# Altium schematic sheet PowerManagement.SchDoc
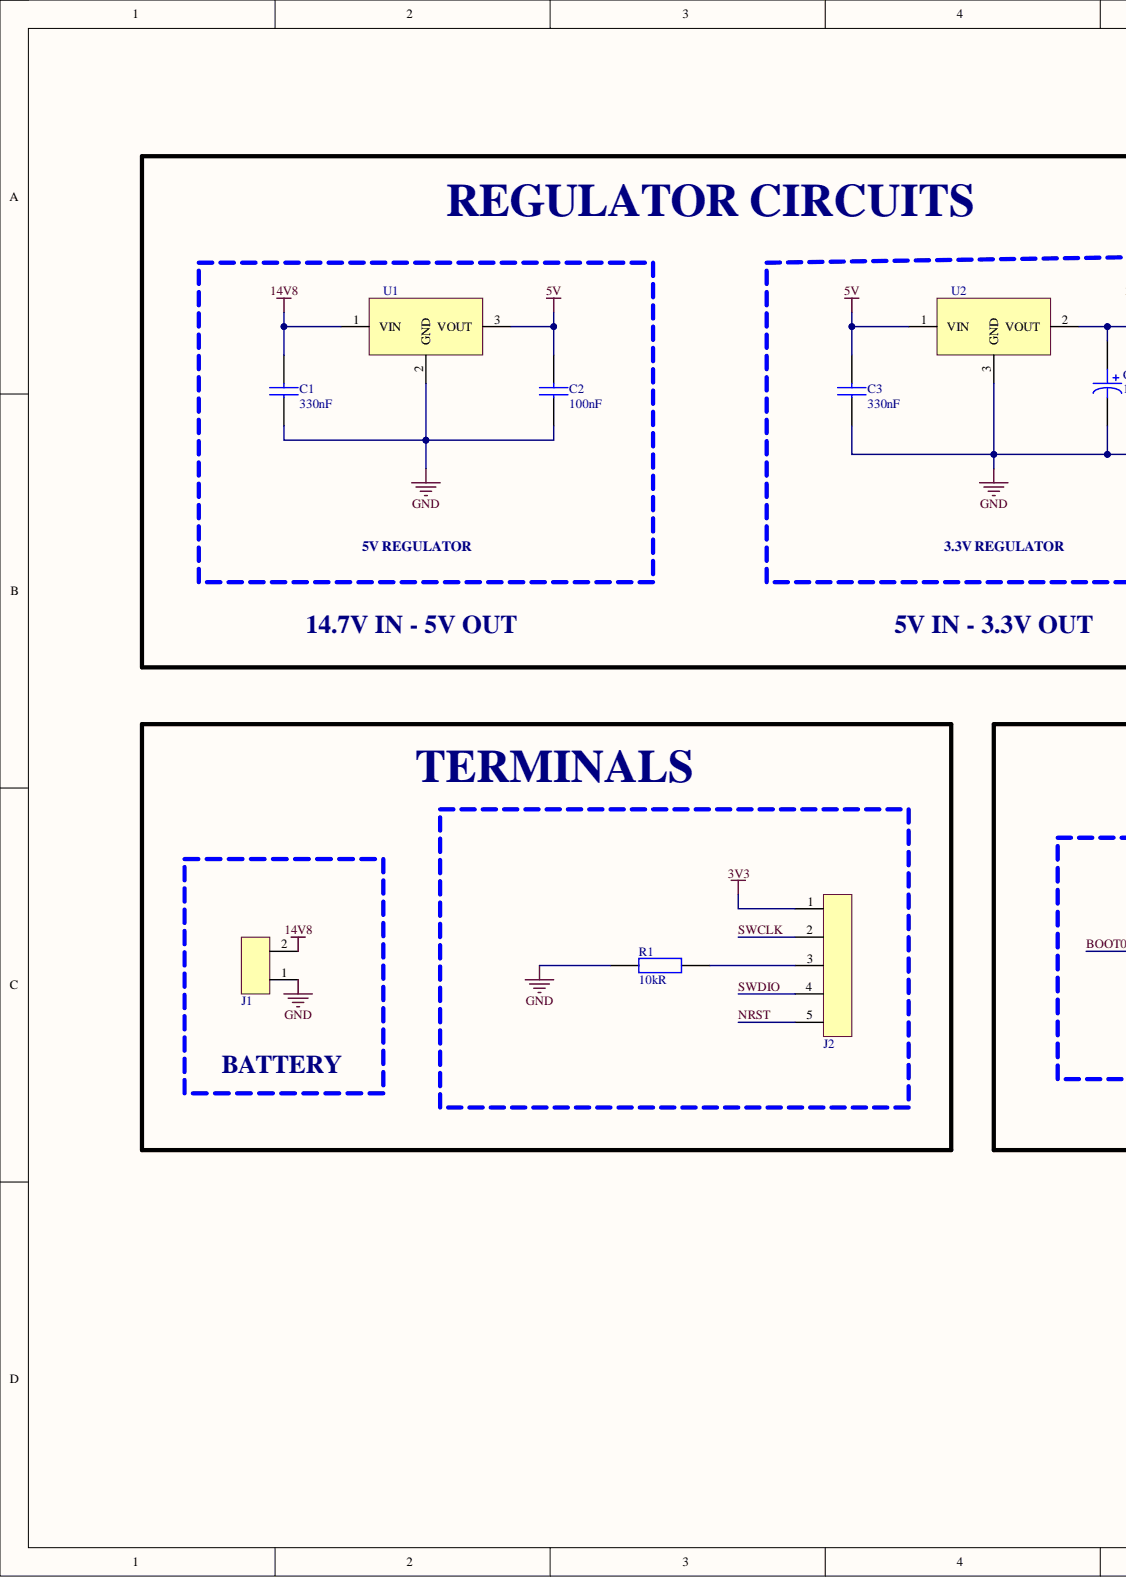
[diagram p0: PowerManagement.SchDoc, left]
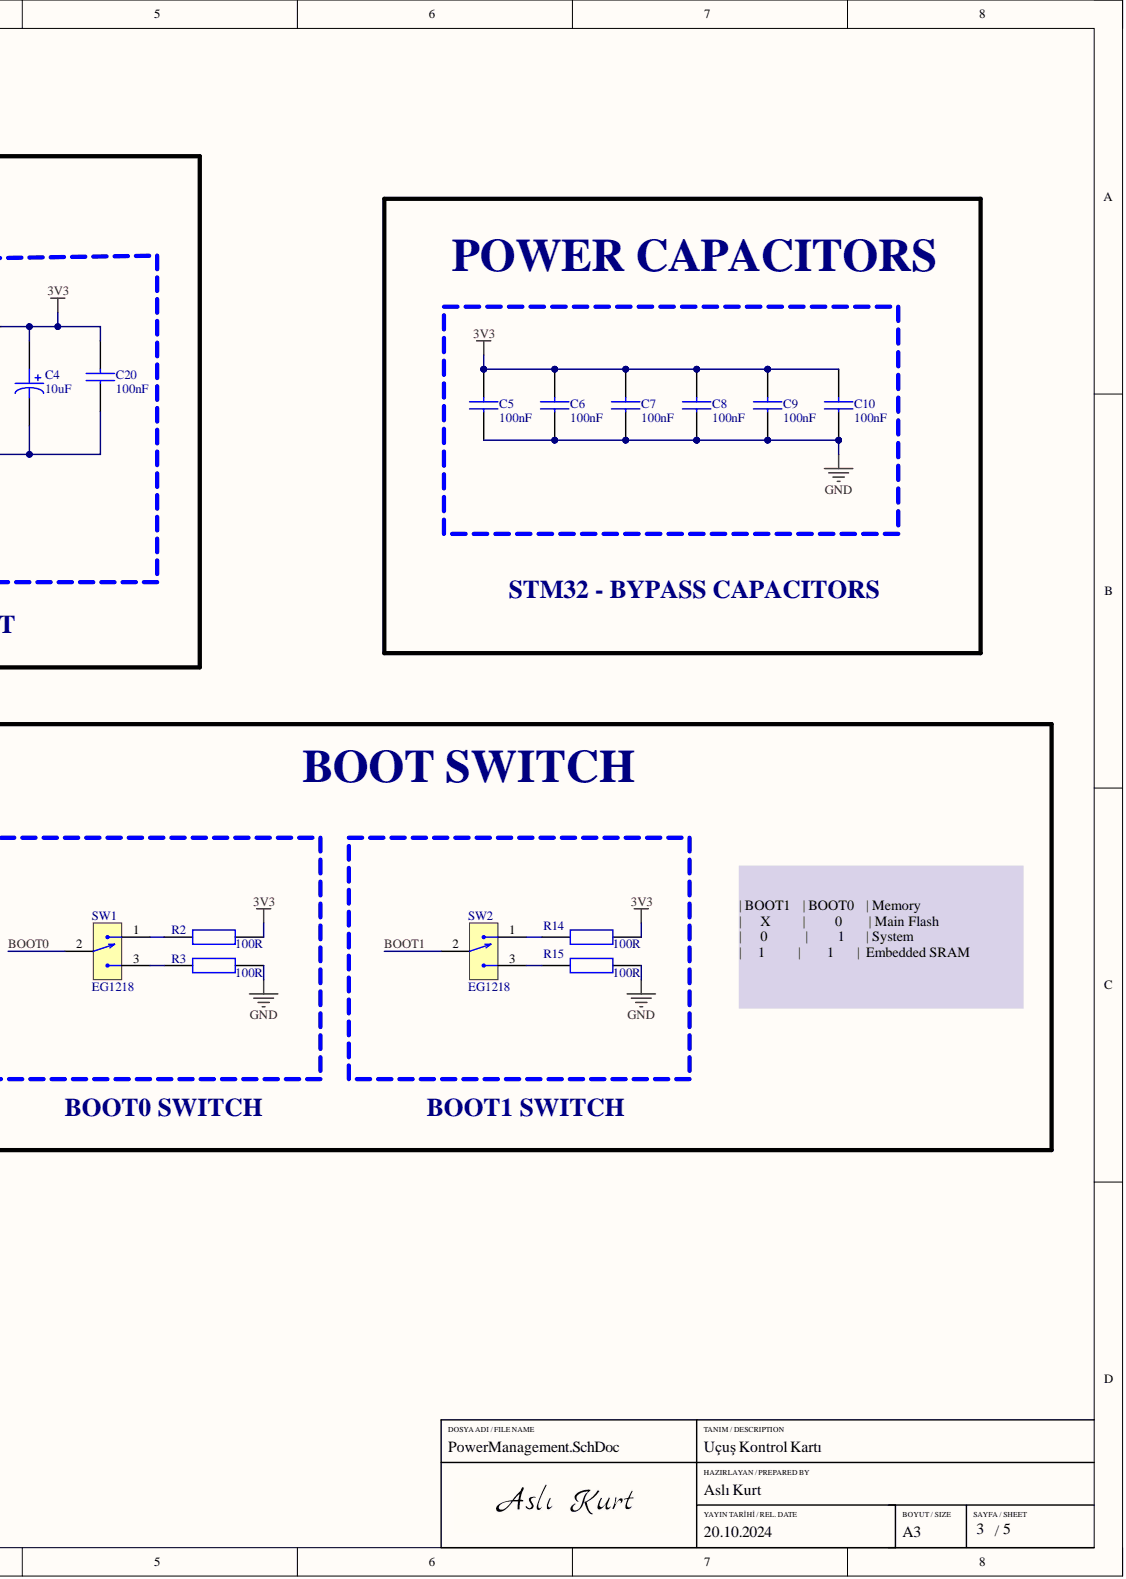
[diagram p1: PowerManagement.SchDoc, right]

## Parts
C1  Capacitance: 330nF  [CAP_0603]  (hidden comment: CGA3E3X7R1H334K080AB)
C2  Capacitance: 100nF  [CAP_0603]  (hidden comment: C0603C104K5RACTU)
C3  Capacitance: 330nF  [CAP_0603]  (hidden comment: CGA3E3X7R1H334K080AB)
C4  Capacitance: 10uF  [CAP_RADIAL_D5_P5.5_SMD]  (hidden comment: 865080642006)
C5  Capacitance: 100nF  [CAP_0603]  (hidden comment: C0603C104K5RACTU)
C6  Capacitance: 100nF  [CAP_0603]  (hidden comment: C0603C104K5RACTU)
C7  Capacitance: 100nF  [CAP_0603]  (hidden comment: C0603C104K5RACTU)
C8  Capacitance: 100nF  [CAP_0603]  (hidden comment: C0603C104K5RACTU)
C9  Capacitance: 100nF  [CAP_0603]  (hidden comment: C0603C104K5RACTU)
C10  Capacitance: 100nF  [CAP_0603]  (hidden comment: C0603C104K5RACTU)
C20  Capacitance: 100nF  [CAP_0603]  (hidden comment: C0603C104K5RACTU)
J1  282836-2  [TERMINAL]  (value not drawn)
J2  61300511121  [5x1 Male Header 2.54mm]  (value not drawn)
R1  Resistance: 10kR  [RES_0805]  (hidden comment: RC0805JR-0710KL)
R2  Resistance: 100R  [RES_0603]  (hidden comment: RC0603JR-07100RL)
R3  Resistance: 100R  [RES_0603]  (hidden comment: RC0603JR-07100RL)
R14  Resistance: 100R  [RES_0603]  (hidden comment: RC0603JR-07100RL)
R15  Resistance: 100R  [RES_0603]  (hidden comment: RC0603JR-07100RL)
SW1  EG1218  [SWITCHSLIDE_1POS_DIP]
SW2  EG1218  [SWITCHSLIDE_1POS_DIP]
U1  Nominal Output Voltage: 5V  [D2PAK-TO-263-3]  (hidden comment: L7805CD2T-TR)
U2  LD29150DT33R  [DPAK-TO252]  (value not drawn)

## Nets
3V3: C4.1 C5.2 C6.2 C7.2 C8.2 C9.2 C10.2 C20.2 J2.1 R2.2 R14.2 U2.2
5V: C2.2 C3.2 U1.3 U2.1
14V8: C1.2 J1.2 U1.1
GND: C1.1 C2.1 C3.1 C4.2 C5.1 C6.1 C7.1 C8.1 C9.1 C10.1 C20.1 J1.1 R1.1 R3.2 R15.2 U1.2 U2.3
BOOT0: SW1.2
BOOT1: SW2.2
NRST: J2.5
SWCLK: J2.2
SWDIO: J2.4
NetJ2_3: J2.3 R1.2
NetR2_1: R2.1 SW1.1
NetR3_1: R3.1 SW1.3
NetR14_1: R14.1 SW2.1
NetR15_1: R15.1 SW2.3

## Library data of the parts
C1 C3 = CAP CER 330nF 0603: Multilayer Ceramic Capacitor, 0.33 uF, 50 V, ± 10%, X7R, 0603 [1608 Metric]; Manufacturer: TDK; DesignedBy: Aslı Kurt; Material: 4241ConductorMaterial; Voltage Rating: 50V; Case Code (Metric): 1608; Case Code (Imperial): 0603; Case/Package: 0603; Category: Ceramic Capacitors; Component Kind: Standard; ComponentLink1Description: http://www.mouser.com/ds/2/400/lcc_automotive_general_en-843974.pdf?src-supplier=Mouser; ComponentLink1URL: http://www.mouser.com/ds/2/400/lcc_automotive_general_en-843974.pdf?src-supplier=Mouser; ComponentLink2Description: https://datasheet.octopart.com/CGA3E3X7R1H334K080AB-TDK-datasheet-43366117.pdf?src-supplier=Future+Electronics; ComponentLink2URL: https://datasheet.octopart.com/CGA3E3X7R1H334K080AB-TDK-datasheet-43366117.pdf?src-supplier=Future+Electronics; ComponentLink3Description: https://product.tdk.com/info/en/documents/chara_sheet/CGA3E3X7R1H334K080AB.pdf?src-supplier=Digikey; ComponentLink3URL: https://product.tdk.com/info/en/documents/chara_sheet/CGA3E3X7R1H334K080AB.pdf?src-supplier=Digikey; ComponentLink4Description: https://product.tdk.com/info/en/catalog/datasheets/mlcc_automotive_general_en.pdf?src-supplier=Digikey; ComponentLink4URL: https://product.tdk.com/info/en/catalog/datasheets/mlcc_automotive_general_en.pdf?src-supplier=Digikey; ComponentLink5Description: http://product.tdk.com/capacitor/mlcc/class/print_detail_pdf.php?lang=en&part_no=CGA3E3X7R1H334K080AB&src-supplier=Avnet; ComponentLink5URL: http://product.tdk.com/capacitor/mlcc/class/print_detail_pdf.php?lang=en&part_no=CGA3E3X7R1H334K080AB&src-supplier=Avnet; ComponentLink6Description: https://datasheet.octopart.com/CGA3E3X7R1H334K080AB-TDK-datasheet-165583812.pdf?src-supplier=TME; ComponentLink6URL: https://datasheet.octopart.com/CGA3E3X7R1H334K080AB-TDK-datasheet-165583812.pdf?src-supplier=TME; Dielectric: X7R; Height: 0.8mm; Length: 0.063inch; Library Name: MyLibrary.IntLib; Manufacturer Part Number: CGA3E3X7R1H334K080AB; Max Operating Temperature: 125°C; Min Operating Temperature: -55°C; Mount: Surface Mount; Package Quantity: 4000; Packaging: Tape and Reel; Pricing 1: 50=0,082, 250=0,066, 500=0,058, 1000=0,049 (GBP); Pricing 2: 1=0,231, 10=0,105, 25=0,093, 50=0,08, 100=0,068 (USD); Pricing 3: 10=0,156, 100=0,0848, 500=0,0677, 1000=0,0505, 2000=0,0453, 4000=0,04, 20000=0,0371, 40000=0,0362 (EUR); Pricing 4: 1=0,23, 10=0,098, 50=0,098, 100=0,063, 1000=0,053, 10000=0,032 (USD); Pricing 5: 1=0,24, 10=0,139, 50=0,0982, 100=0,0858, 500=0,06458, 1000=0,05797 (USD); Ratings: AEC-Q200; RoHS: Yes; RoHS Compliant: Yes; Series: CGA; Stock 1: 1350; Stock 2: 26394; Stock 3: 76750; Stock 4: 154875; Stock 5: 1242048; Supplier 1: Rs; Supplier 2: Avnet; Supplier 3: Farnell; Supplier 4: Mouser; Supplier 5: Digikey; Supplier Part Number 1: 2427476; Supplier Part Number 2: 71AH2809; Supplier Part Number 3: 3416368; Supplier Part Number 4: 810-CGA3E3X7R1H334KB; Supplier Part Number 5: 445-174426-1-ND; Termination: SMD/SMT; Thickness: 0.035inch; Tolerance: 10%; Voltage Rating (DC): 50V; Weight: 7.1E-05oz; Width: 0.031inch
C2 C5-C10 C20 = CAP CER 100nF 0603: CAP CER 100nF %10 0603 SMD; Manufacturer: KEMET; DesignedBy: Aslı Kurt; Dielectric: X7R; Dielectric Material: Ceramic; Dissipation Factor: 2.5%; Tolerance: 10%; Voltage: 50V; Case Code (Metric): 1608; Case Code (Imperial): 0603; Case/Package: 0603; Category: Ceramic Capacitors; Component Kind: Standard; ComponentLink1Description: https://datasheet.ciiva.com/7823/kem-c1002-x7r-smd-7823591.pdf?src-supplier=Element14; ComponentLink1URL: https://datasheet.ciiva.com/7823/kem-c1002-x7r-smd-7823591.pdf?src-supplier=Element14; ComponentLink2Description: https://datasheet.ciiva.com/14602/70095383-14602583.pdf?src-supplier=Allied+Electronics; ComponentLink2URL: https://datasheet.ciiva.com/14602/70095383-14602583.pdf?src-supplier=Allied+Electronics; ComponentLink3Description: https://datasheet.ciiva.com/5999/kem-c1002-x7r-smd-5999400.pdf?src-supplier=Farnell; ComponentLink3URL: https://datasheet.ciiva.com/5999/kem-c1002-x7r-smd-5999400.pdf?src-supplier=Farnell; ComponentLink4Description: https://datasheet.ciiva.com/7823/kem-c1002-x7r-smd-7823592.pdf?src-supplier=Element14; ComponentLink4URL: https://datasheet.ciiva.com/7823/kem-c1002-x7r-smd-7823592.pdf?src-supplier=Element14; ComponentLink5Description: https://datasheet.ciiva.com/28645/1851736-28645640.pdf?src-supplier=Element14; ComponentLink5URL: https://datasheet.ciiva.com/28645/1851736-28645640.pdf?src-supplier=Element14; ComponentLink6Description: https://datasheet.ciiva.com/14585/70095383-14585209.pdf?src-supplier=Allied+Electronics; ComponentLink6URL: https://datasheet.ciiva.com/14585/70095383-14585209.pdf?src-supplier=Allied+Electronics; Contact Plating: Tin,Matte; Depth: 0.8mm; Height: 0.8mm; Lead Pitch: 0.7mm; Length: 0.063inch; Library Name: MyLibrary.IntLib; Manufacturer Part Number: C0603C104K5RACTU; Max Operating Temperature: 125°C; Min Operating Temperature: -55°C; Mount: Surface Mount; Number of Pins: 2; Package Quantity: 1; Packaging: Tape and Reel; Pricing 1: 1=0,12000, 10=0,08500, 50=0,04620, 100=0,03770, 500=0,02696, 1000=0,02118 (USD); Radiation Hardening: No; REACH SVHC: No SVHC; RoHS: Yes; RoHS Compliant: Yes; Series: SMD Comm X7R; Stock 1: 1666186; Supplier 1: Digi-Key; Supplier Part Number 1: 399-5089-1-ND; Termination: SMD/SMT; Thickness: 0.034inch; Voltage Rating: 50V; Voltage Rating (DC): 50V; Width: 0.031inch
C4 = CAP ALU ELEC 10uF  50V: CAP ALUM 10UF 20% 50V SMD; Manufacturer: Wurth Electronics; DesignedBy: Aslı Kurt; ESR (Equivalent Series Resistance): 1.63932R; Leakage Current: 5uA; Ripple Current: 95mA; Impedance: 2.8R; Insulation Resistance: 10MR; Case/Package: Radial; Category: Aluminum Electrolytic Capacitors; Component Kind: Standard; ComponentLink1Description: http://www.farnell.com/datasheets/1880176.pdf?src-supplier=Farnell; ComponentLink1URL: http://www.farnell.com/datasheets/1880176.pdf?src-supplier=Farnell; ComponentLink2Description: http://www.farnell.com/datasheets/1880176.pdf?src-supplier=Element14; ComponentLink2URL: http://www.farnell.com/datasheets/1880176.pdf?src-supplier=Element14; ComponentLink3Description: http://www.farnell.com/datasheets/1880176.pdf?src-supplier=Newark; ComponentLink3URL: http://www.farnell.com/datasheets/1880176.pdf?src-supplier=Newark; ComponentLink4Description: http://katalog.we-online.de/pbs/datasheet/865080642006.pdf?src-supplier=Digikey; ComponentLink4URL: http://katalog.we-online.de/pbs/datasheet/865080642006.pdf?src-supplier=Digikey; ComponentLink5Description: https://katalog.we-online.de/pbs/datasheet/865080642006.pdf?src-supplier=Digikey; ComponentLink5URL: https://katalog.we-online.de/pbs/datasheet/865080642006.pdf?src-supplier=Digikey; Diameter: 5mm; Height: 5.5mm; Height - Seated (Max): 0.217inch; Length: 5.5mm; Library Name: MyLibrary.IntLib; Manufacturer Part Number: 865080642006; Max Operating Temperature: 105°C; Min Operating Temperature: -55°C; Mount: Surface Mount; Package Quantity: 1; Packaging: Cut Tape; Pricing 1: 25=0,16 (GBP); Pricing 2: 1=0,27, 50=0,165, 100=0,154, 250=0,128, 500=0,112, 1000=0,108, 5000=0,107, 10000=0,105 (EUR); Pricing 3: 1=0,2, 10=0,2, 50=0,174, 100=0,151, 1000=0,112, 10000=0,112 (USD); Pricing 4: 1=0,2, 50=0,174, 100=0,151, 250=0,134, 500=0,118 (USD); Reach SVHC Compliant: Unknown; Ripple Current (AC): 95mA; RoHS: Yes; RoHS Compliant: Yes; Series: WCAP-ASLI; Stock 1: 250; Stock 2: 875; Stock 3: 13568; Stock 4: 72984; Supplier 1: Rs; Supplier 2: Farnell; Supplier 3: Mouser; Supplier 4: Digikey; Supplier Part Number 1: 8391862; Supplier Part Number 2: 2466333; Supplier Part Number 3: 710-865080642006; Supplier Part Number 4: 732-8451-1-ND; Tolerance: 20%; Voltage Rating: 50V; Voltage Rating (DC): 50V; Width: 5.3mm
J1 = 2x1 TERMINAL 5mm: Connector, Terminal Block; Wire Receptacle; 2 Pos.; 16-30 AWG; 0.197 in. CL; Sid; Manufacturer: TE Connectivity; Approvals: UL; Closed End: No (Without); Connector System: 5937ConnectorSystem; Current: 13.5A; DesignedBy: Aslı Kurt; Max Supply Voltage (AC): 300V; Number of Circuits: 2; Number of Levels: 1; Pitch: 5mm; Plating: Brass; Side Stackable: Yes (With); Tail Length: 3.5mm; Thread Size: M3; Voltage Rating (DC): 250V; Wire Gauge (Max): 30AWG; Category: Terminal Blocks; Color: Green; Component Kind: Standard; ComponentLink1Description: https://datasheet.ciiva.com/7628/1731782-7628513.pdf?src-supplier=Element14; ComponentLink1URL: https://datasheet.ciiva.com/7628/1731782-7628513.pdf?src-supplier=Element14; ComponentLink2Description: https://datasheet.ciiva.com/16393/282836-2-16393382.pdf?src-supplier=Future+Electronics; ComponentLink2URL: https://datasheet.ciiva.com/16393/282836-2-16393382.pdf?src-supplier=Future+Electronics; ComponentLink3Description: https://datasheet.ciiva.com/15169/getdatasheetpartid-155855-15169553.pdf?src-supplier=Verical; ComponentLink3URL: https://datasheet.ciiva.com/15169/getdatasheetpartid-155855-15169553.pdf?src-supplier=Verical; ComponentLink4Description: https://datasheet.ciiva.com/11757/0900766b8002dc8a-11757385.pdf?src-supplier=Rs; ComponentLink4URL: https://datasheet.ciiva.com/11757/0900766b8002dc8a-11757385.pdf?src-supplier=Rs; ComponentLink5Description: https://datasheet.ciiva.com/823/eng-cd-282836-h10-823437.pdf?src-supplier=Digikey; ComponentLink5URL: https://datasheet.ciiva.com/823/eng-cd-282836-h10-823437.pdf?src-supplier=Digikey; ComponentLink6Description: https://datasheet.ciiva.com/3141/eng-cs-1308389-eurostyle-terminal-blocks-0607-3141118.pdf?src-supplier=Avnet; ComponentLink6URL: https://datasheet.ciiva.com/3141/eng-cs-1308389-eurostyle-terminal-blocks-0607-3141118.pdf?src-supplier=Avnet; ComponentLink7Description: https://datasheet.ciiva.com/30261/eng-cs-1308389-eurostyle-terminal-blocks-0607-30261813.pdf?src-supplier=Onlinecomponents.com; ComponentLink7URL: https://datasheet.ciiva.com/30261/eng-cs-1308389-eurostyle-terminal-blocks-0607-30261813.pdf?src-supplier=Onlinecomponents.com; Connector Type: Receptacle; Contact Material: Brass; Contact Plating: Tin; Current Rating: 13.5A; Depth: 8.2mm; ELV: Compliant; Flammability Rating: UL94 V-0; Housing Color: Green; Housing Material: Polyamide; Lead Free: Lead Free; Length: 10.6mm; Library Name: MyLibrary.IntLib; Manufacturer Part Number: 282836-2; Max Current Rating: 13.5A; Max Operating Temperature: 105°C; Max Voltage Rating (AC): 300V; Min Operating Temperature: -40°C; Mount: Through Hole; Number of Contacts: 2; Number of Poles: 2; Number of Positions: 2; Number of Positions Per Level: 2; Number of Rows: 1; Operating Supply Voltage: 300V; Orientation: Straight; Package Quantity: 300; Packaging: Tray; Pricing 1: 1=0,6, 10=0,578, 25=0,576, 50=0,5566, 100=0,4666, 300=0,43743, 600=0,41315, 1200=0,36211, 5100=0,31593 (USD); Radiation Hardening: No; Reach SVHC Compliant: Unknown; RoHS: Yes; RoHS Compliant: Yes; Screw Flange: No (Without); Screwless Terminal Block: No (Without); Series: Buchanan; Stock 1: 33835; Supplier 1: Digikey; Supplier Part Number 1: A98076-ND; Termination: Solder; Voltage: 300V; Voltage Rating: 300V; Width: 10mm; Wire Gauge (Min): 30AWG; Wire/Cable Gauge: 30AWG; Insulation Material: Nylon; Interlock: Yes (With)
J2 = 5x1 Male Header 2.54mm: DesignedBy: Aslı Kurt; Component Kind: Standard; Library Name: MyLibrary.IntLib
R1 = RES 10K OHM 0805: RES 10K OHM 5% 1/8W 0805; Manufacturer: Yageo; DesignedBy: Aslı Kurt; Max Power Dissipation: 125mW; Temperature Coefficient: 100ppm/°C; Voltage Rating: 150V; Case Code (Metric): 2012; Case Code (Imperial): 0805; Case/Package: 0805; Category: Chip SMD Resistors; Component Kind: Standard; ComponentLink1Description: https://datasheet.ciiva.com/7983/444164-7983765.pdf?src-supplier=Element14; ComponentLink1URL: https://datasheet.ciiva.com/7983/444164-7983765.pdf?src-supplier=Element14; ComponentLink2Description: https://datasheet.ciiva.com/7941/444164-7941870.pdf?src-supplier=Element14; ComponentLink2URL: https://datasheet.ciiva.com/7941/444164-7941870.pdf?src-supplier=Element14; ComponentLink3Description: https://datasheet.ciiva.com/7941/444164-7941871.pdf?src-supplier=Element14; ComponentLink3URL: https://datasheet.ciiva.com/7941/444164-7941871.pdf?src-supplier=Element14; Construction: Rectangular; Contact Plating: Tin,Matte; Depth: 1.25mm; Features: Moisture Resistant; Height: 0.5mm; Lead Free: 5697LeadFree; Length: 2mm; Library Name: MyLibrary.IntLib; Manufacturer Part Number: RC0805JR-0710KL; Max Operating Temperature: 155°C; Military Standard: Not; Min Operating Temperature: -55°C; Number of Terminations: 2; Packaging: Tape and Reel; Power Rating: 125mW; Pricing 1: 1=0,1, 10=0,023, 50=0,0144, 100=0,012, 500=0,00818, 1000=0,00706 (USD); Radiation Hardening: No; Reach SVHC Compliant: Unknown; Resistance Tolerance: 5%; Resistor Type: Thick Film; RoHS: Yes; RoHS Compliant: Yes; Series: RC; Size Code: 0805; Stock 1: 444162; Supplier 1: Digikey; Supplier Part Number 1: 311-10KARCT-ND; Termination: SMD/SMT; Tolerance: 5%; Width: 1.25mm
R2 R3 R14 R15 = RES 100 OHM 0603: RES 100 %5 OHM 0603 SMD; Manufacturer: Yageo; DesignedBy: Aslı Kurt; Max Power Dissipation: 100mW; Resistance Tolerance: 5%; Temperature Coefficient: 100ppm/°C; Voltage Rating: 50V; Case Code (Metric): 1608; Case Code (Imperial): 0603; Case/Package: 0603; Category: Chip SMD Resistors; Component Kind: Standard; ComponentLink1Description: https://datasheet.ciiva.com/15189/getdatasheetpartid-325207-15189846.pdf?src-supplier=Verical; ComponentLink1URL: https://datasheet.ciiva.com/15189/getdatasheetpartid-325207-15189846.pdf?src-supplier=Verical; ComponentLink2Description: https://datasheet.ciiva.com/8883/pyu-rc0603-51-rohs-l-4-8883047.pdf?src-supplier=Avnet; ComponentLink2URL: https://datasheet.ciiva.com/8883/pyu-rc0603-51-rohs-l-4-8883047.pdf?src-supplier=Avnet; ComponentLink3Description: https://datasheet.ciiva.com/7746/443971-7746425.pdf?src-supplier=Element14; ComponentLink3URL: https://datasheet.ciiva.com/7746/443971-7746425.pdf?src-supplier=Element14; ComponentLink4Description: https://datasheet.ciiva.com/7746/443971-7746426.pdf?src-supplier=Element14; ComponentLink4URL: https://datasheet.ciiva.com/7746/443971-7746426.pdf?src-supplier=Element14; Construction: Rectangular; Contact Plating: Tin,Matte; Depth: 0.8mm; Features: Moisture Resistant; Lead Free: Lead Free; Length: 1.6mm; Library Name: MyLibrary.IntLib; Manufacturer Part Number: RC0603JR-07100RL; Max Operating Temperature: 155°C; Military Standard: Not; Min Operating Temperature: -55°C; Number of Pins: 2; Number of Terminations: 2; Packaging: Tape and Reel; Power Rating: 100mW; Pricing 1: 1=0,10000, 10=0,01900, 100=0,00770, 1000=0,00344, 2500=0,00298 (USD); Radiation Hardening: No; REACH SVHC: Unknown; Resistor Type: Thick Film; RoHS: Yes; RoHS Compliant: Yes; Series: RC; Size Code: 0603; Stock 1: 4334796; Supplier 1: Digi-Key; Supplier Part Number 1: 311-100GRCT-ND; Termination: SMD/SMT; Tolerance: 5%; Voltage Rating (DC): 50V; Width: 0.8mm
SW1 SW2 = EG1218: Slide Switch, SPDT, ON-ON, 200 mA, 30V DC, TH, PC Pin, EG Series | E-Switch EG1218; Actuator Length: 2mm; Actuator Type: Standard; Contact Current Rating: 200mA; Contact Resistance: 20mR; DesignedBy: Aslı Kurt; Dropout Voltage: 300mV; Electrical Life: 10000Cycles; Max Output Current: 150mA; Min Input Voltage: 4.3V; Nominal Output Voltage: 3.3V; Number of Positions: 2; Operating Force: 250gf; Power Rating: 250mW; Switch Travel: 2.5mm; Illumination Type: Non-Illuminated; Insulation Resistance: 100R; Case/Package: 947Case; Category: Slide Switches; Component Kind: Standard; ComponentLink1Description: https://datasheet.ciiva.com/1699/p040040c-1699451.pdf?src-supplier=Newark; ComponentLink1URL: https://datasheet.ciiva.com/1699/p040040c-1699451.pdf?src-supplier=Newark; Contact Material: Copper Alloy,Copper,Silver; Contact Plating: Silver; Lead Free: Lead Free; Library Name: MyLibrary.IntLib; Manufacturer: E-Switch; Manufacturer Part Number: EG1218; Max Operating Temperature: 70°C; Min Operating Temperature: -20°C; Mount: Through Hole; Number of Pins: 3; Orientation: Straight; Output Current: 150mA; Output Voltage: 3.3V; Packaging: Bulk; Pricing 1: 1=0,43, 100=0,41, 200=0,4, 300=0,435, 500=0,385, 1000=0,42, 1250=0,36, 3000=0,39 (USD); Pricing 2: 1=0,43, 100=0,41, 200=0,4, 500=0,385, 1250=0,36 (USD); Pricing 3: 10=0,683, 50=0,657, 100=0,571, 250=0,52 (EUR); Pricing 4: 1=0,88, 10=0,72, 25=0,6628, 100=0,5837, 250=0,53608, 500=0,5023, 1000=0,47033, 3000=0,4231, 5000=0,40248 (USD); Pricing 5: 1=0,75, 10=0,66, 50=0,66, 100=0,554, 1000=0,458, 10000=0,402 (USD); Radiation Hardening: 5898YesNo; Reach SVHC Compliant: No; RoHS: Yes; RoHS Compliant: Yes; Series: EG; Stock 1: 30199; Stock 2: 8336; Stock 3: 1815; Stock 4: 31000; Stock 5: 14215; Supplier 1: Future Electronics; Supplier 2: Future Electronics; Supplier 3: Farnell; Supplier 4: Digikey; Supplier 5: Mouser; Supplier Part Number 1: 9403163; Supplier Part Number 2: 7125310; Supplier Part Number 3: 2787232; Supplier Part Number 4: EG1903-ND; Supplier Part Number 5: 612-EG1218; Switch Function: On-On; Termination: PC Pin; Throw Configuration: SPDT; Voltage Rating: 30V; Voltage Rating (AC): 30V; Voltage Rating (DC): 30V
U1 = L7805CD2T-TR: Standard Regulator Pos 5V 1.5A 3-Pin(2+Tab) D2PAK T/R / IC REG LDO 5V 1.5A D2PAK; Manufacturer: STMicroelectronics; +Sicaklik: +125; -Sicaklik: -40; DesignedBy: Aslı Kurt; Dropout Voltage: 2V; Kılıf: DPAK; Max Input Voltage: 35V; Min Output Voltage: 4.8V; Min Input Voltage: 10V; Nominal Supply Current: 4.3mA; Number of Channels: 1NUMBER; Number of Outputs: 1; Output Type: Fixed; Polarity: Positive; Power Supply Rejection Ratio (PSRR): 62dB; Quiescent Current: 8mA; Uretici Kodu: L7805CDT-TR; Case/Package: TO-263; Category: Voltage Regulators - Linear; Cins: IC; Component Kind: Standard; ComponentLink1Description: https://datasheet.ciiva.com/36526/1991686-36526238.pdf?src-supplier=Jameco; ComponentLink1URL: https://datasheet.ciiva.com/36526/1991686-36526238.pdf?src-supplier=Jameco; ComponentLink2Description: https://datasheet.ciiva.com/1511/1805459-1511910.pdf?src-supplier=Element14; ComponentLink2URL: https://datasheet.ciiva.com/1511/1805459-1511910.pdf?src-supplier=Element14; ComponentLink3Description: https://datasheet.ciiva.com/2053/cd00000444-2053730.pdf?src-supplier=Digikey; ComponentLink3URL: https://datasheet.ciiva.com/2053/cd00000444-2053730.pdf?src-supplier=Digikey; ComponentLink4Description: https://datasheet.ciiva.com/4881/cd00000444-4881992.pdf?src-supplier=Mouser; ComponentLink4URL: https://datasheet.ciiva.com/4881/cd00000444-4881992.pdf?src-supplier=Mouser; ComponentLink5Description: https://datasheet.ciiva.com/16385/cd00000444-16385288.pdf?src-supplier=Future+Electronics; ComponentLink5URL: https://datasheet.ciiva.com/16385/cd00000444-16385288.pdf?src-supplier=Future+Electronics; ComponentLink6Description: https://datasheet.ciiva.com/46618/1805459-46618855.pdf?src-supplier=Newark; ComponentLink6URL: https://datasheet.ciiva.com/46618/1805459-46618855.pdf?src-supplier=Newark; ComponentLink7Description: https://datasheet.ciiva.com/22710/1805459-22710937.pdf?src-supplier=Element14; ComponentLink7URL: https://datasheet.ciiva.com/22710/1805459-22710937.pdf?src-supplier=Element14; ComponentLink8Description: https://datasheet.ciiva.com/7647/1805459-7647813.pdf?src-supplier=Element14; ComponentLink8URL: https://datasheet.ciiva.com/7647/1805459-7647813.pdf?src-supplier=Element14; ComponentLink9Description: https://datasheet.ciiva.com/2053/cd00000444-2053731.pdf?src-supplier=Digikey; ComponentLink9URL: https://datasheet.ciiva.com/2053/cd00000444-2053731.pdf?src-supplier=Digikey; ComponentLink10Description: https://datasheet.ciiva.com/2053/cd00000444-2053732.pdf?src-supplier=Digikey; ComponentLink10URL: https://datasheet.ciiva.com/2053/cd00000444-2053732.pdf?src-supplier=Digikey; Height: 4.6mm; Lead Free: Lead Free; Length: 10.4mm; Library Name: MyLibrary.IntLib; Manufacturer Part Number: L7805CD2T-TR; Max Operating Temperature: 125°C; Max Output Current: 1.5A; Min Operating Temperature: 0°C; Mount: Surface Mount; Number of Pins: 3; Number of Regulators: 1; Output Current: 1.5A; Output Voltage: 5V; Package Quantity: 1000; Packaging: Tape and Reel; Pricing 1: 1000=0,31, 3000=0,3, 5000=0,295, 10000=0,29, 20000=0,28 (USD); Pricing 2: 1=0,83, 10=0,685, 50=0,685, 100=0,52, 1000=0,348, 10000=0,287 (USD); Pricing 3: 50=0,516, 100=0,49, 250=0,463, 500=0,441 (GBP); Pricing 4: 1=0,886, 10=0,741, 25=0,686, 50=0,631, 100=0,576 (USD); Pricing 5: 1=0,886, 10=0,702, 50=0,486, 200=0,45, 500=0,413 (EUR); Pricing 6: 1=0,83, 10=0,729, 25=0,6848, 100=0,5588, 250=0,51908, 500=0,44176 (USD); Reach SVHC Compliant: No; RoHS: Yes; RoHS Compliant: Yes; Series: -; Stock 1: 202000; Stock 2: 13102; Stock 3: 6020; Stock 4: 704; Stock 5: 8724; Stock 6: 13327; Supplier 1: Future Electronics; Supplier 2: Mouser; Supplier 3: Rs; Supplier 4: Avnet; Supplier 5: Farnell; Supplier 6: Digikey; Supplier Part Number 1: 4623278; Supplier Part Number 2: 511-L7805CD2T-TR; Supplier Part Number 3: 7140657P; Supplier Part Number 4: 95M4314; Supplier Part Number 5: 1366576; Supplier Part Number 6: 497-1171-1-ND; Weight: 7.1E-05oz; Width: 9.35mm
U2 = LD29150DT33R: LD29150 Series 3.3 V 1.5 A Fixed Output SMT LDO Voltage Regulator - TO-252; Manufacturer: STMicroelectronics; +Sicaklik: +125; -Sicaklik: -40; Cins: IC; DesignedBy: Aslı Kurt; Kılıf: DPAK; Uretici Kodu: LD1086DT33TR; Category: Voltage Regulators - Linear; Component Kind: Standard; ComponentLink1Description: https://datasheet.ciiva.com/1569/1788499-1569956.pdf?src-supplier=Farnell; ComponentLink1URL: https://datasheet.ciiva.com/1569/1788499-1569956.pdf?src-supplier=Farnell; ComponentLink2Description: https://datasheet.ciiva.com/41440/001184976-da-01-en-ic-reg-ldo-3-3-ld29150dt33r-to-252-3-stm-41440118.pdf?src-supplier=Conrad; ComponentLink2URL: https://datasheet.ciiva.com/41440/001184976-da-01-en-ic-reg-ldo-3-3-ld29150dt33r-to-252-3-stm-41440118.pdf?src-supplier=Conrad; ComponentLink3Description: https://datasheet.ciiva.com/2044/cd00003403-2044031.pdf?src-supplier=Digikey; ComponentLink3URL: https://datasheet.ciiva.com/2044/cd00003403-2044031.pdf?src-supplier=Digikey; ComponentLink4Description: https://datasheet.ciiva.com/2044/cd00003403-2044032.pdf?src-supplier=Digikey; ComponentLink4URL: https://datasheet.ciiva.com/2044/cd00003403-2044032.pdf?src-supplier=Digikey; ComponentLink5Description: https://datasheet.ciiva.com/2044/cd00003403-2044033.pdf?src-supplier=Digikey; ComponentLink5URL: https://datasheet.ciiva.com/2044/cd00003403-2044033.pdf?src-supplier=Digikey; ComponentLink6Description: https://datasheet.ciiva.com/22694/1904189-22694855.pdf?src-supplier=Element14; ComponentLink6URL: https://datasheet.ciiva.com/22694/1904189-22694855.pdf?src-supplier=Element14; Library Name: MyLibrary.IntLib; Manufacturer Part Number: LD29150DT33R; Pricing 1: 2500=0,715, 5000=0,7, 7500=0,69, 10000=0,685, 12500=0,665 (USD); Pricing 2: 1=1,61, 10=1,37, 50=1,37, 100=1,1, 1000=0,789, 10000=0,68 (USD); Pricing 3: 10=1,218, 100=0,826, 500=0,782, 1000=0,61 (GBP); Pricing 4: 1=1,61, 10=1,443, 25=1,3608, 100=1,1596, 250=1,0888, 500=0,95268, 1000=0,78937 (USD); RoHS: No; Stock 1: 10000; Stock 2: 10050; Stock 3: 170; Stock 4: 12678; Supplier 1: Future Electronics; Supplier 2: Mouser; Supplier 3: Rs; Supplier 4: Digikey; Supplier Part Number 1: 6030624; Supplier Part Number 2: 511-LD29150DT33R; Supplier Part Number 3: 6868704P; Supplier Part Number 4: 497-1496-1-ND
